# Altium schematic sheet sch.SchDoc
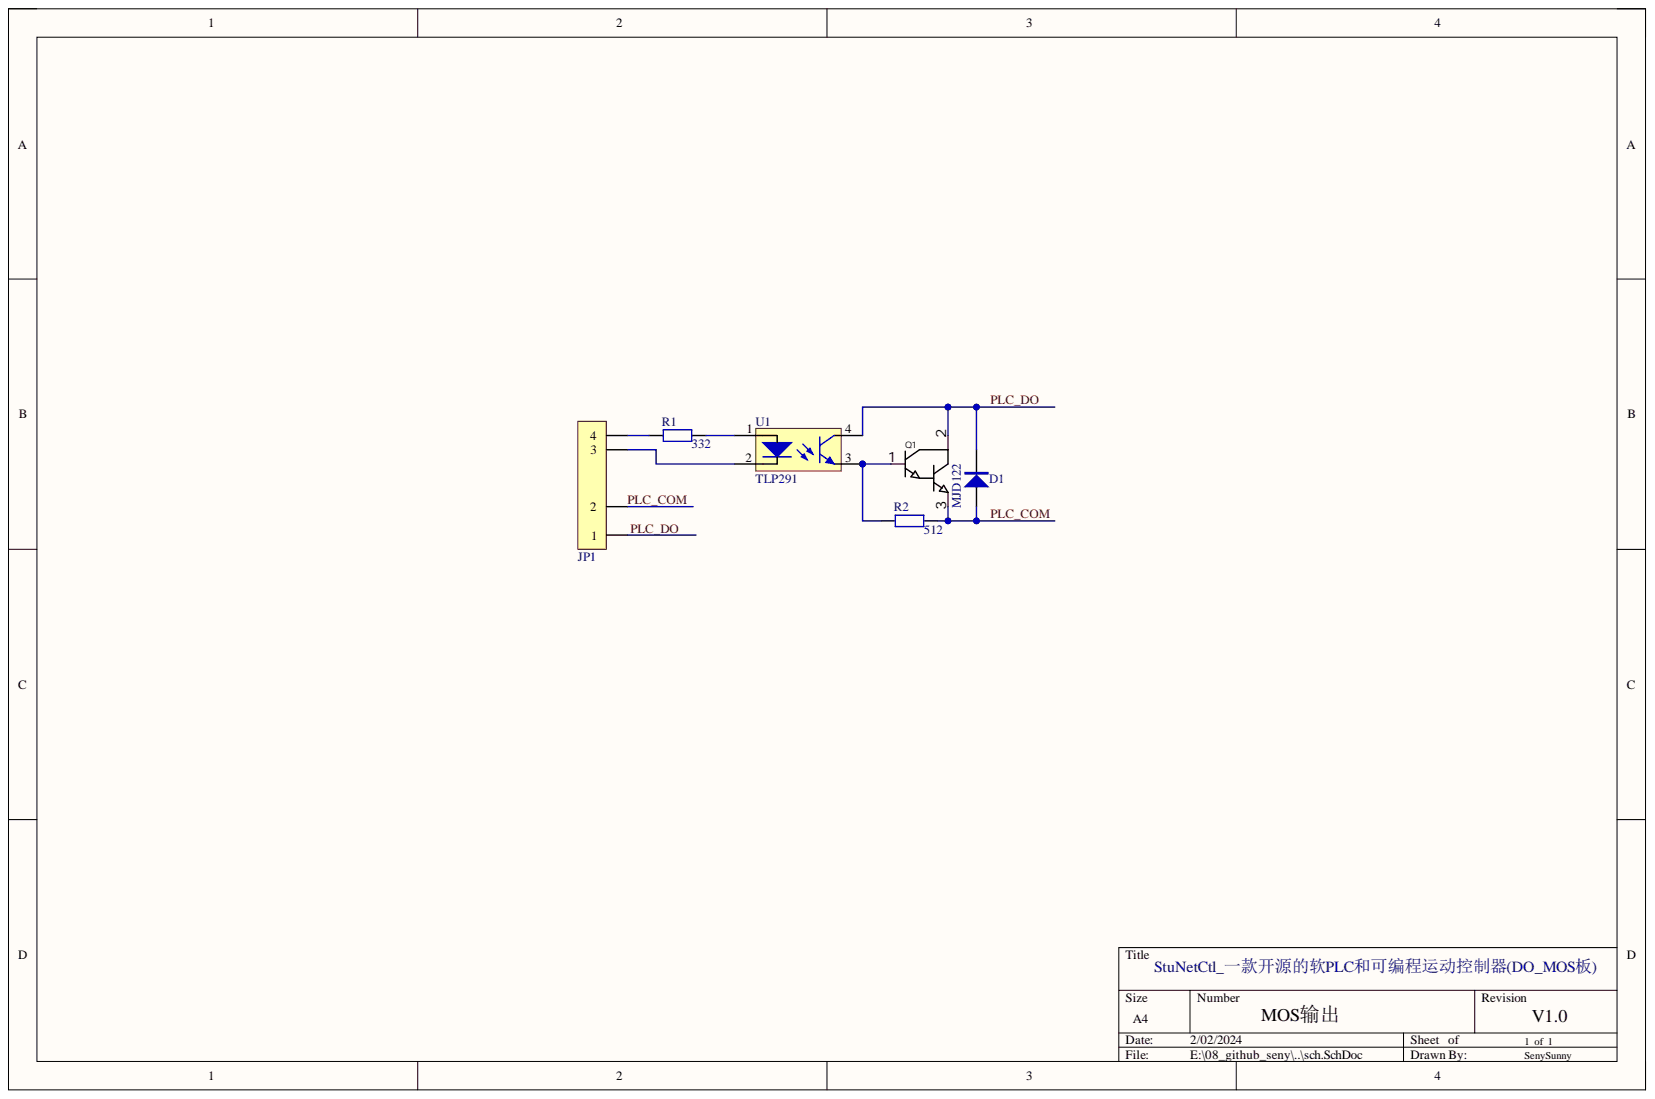
[diagram p0: sch.SchDoc]

## Parts
D1  MJD122  [SMA(DO214AC)]
JP1  HDR-1X4_DO  [HDR-1X4_H_do1]  (value not drawn)
Q1  MJD122  [TO252]
R1  332  [R 0603]
R2  512  [R 0603]
U1  TLP291  [SOP4_L_P1.27]

## Nets
PLC_COM: D1.1 JP1.2 Q1.3 R2.2
PLC_DO: D1.2 JP1.1 Q1.2 U1.4
NetJP1_3: JP1.3 U1.2
NetJP1_4: JP1.4 R1.1
NetQ1_1: Q1.1 R2.1 U1.3
NetR1_2: R1.2 U1.1

## Library data of the parts
D1 = M7: 整流二极管; 版权归属: 源创客; BOM名称: 整流二极管; Component Kind: Standard; 学习资源: www.ooocke.com; Library Name: zblib_v1.IntLib
JP1 = Header 4_DO: 4P_DO模块接插件; BOM名称: 8P接插件; 版权归属: 源创客; Component Kind: Standard; 学习资源: www.ooocke.com; Library Name: zblib_v1.IntLib
Q1 = MJD122: BJT达林顿晶体管(NPN); Manufacturer: CJ(江苏长电/长晶); Add into BOM: yes; Device: MJD122_C59488; Component Kind: Standard; Convert to PCB: yes; Datasheet: https://atta.szlcsc.com/upload/public/pdf/source/20160918/1474166836957.pdf; JLCPCB Part Class: Extended Part; LCSC Part Name: MJD122; Manufacturer Part: MJD122; Name: MJD122; Supplier: LCSC; Supplier Footprint: TO-252-2(DPAK); Supplier Part: C59488; Symbol: MJD122; Library Name: zblib_v1.IntLib
R1 R2 = R: 贴片电阻; 版权归属: 源创客; BOM名称: 贴片电阻; Component Kind: Standard; 学习资源: www.ooocke.com; Library Name: zblib_v1.IntLib
U1 = TLP291: 光耦，小体积，间距50mil; Component Kind: Standard; Library Name: zblib_v1.IntLib
